# Altium schematic sheet DDR3-RAM.SchDoc(DDR3-RAM)
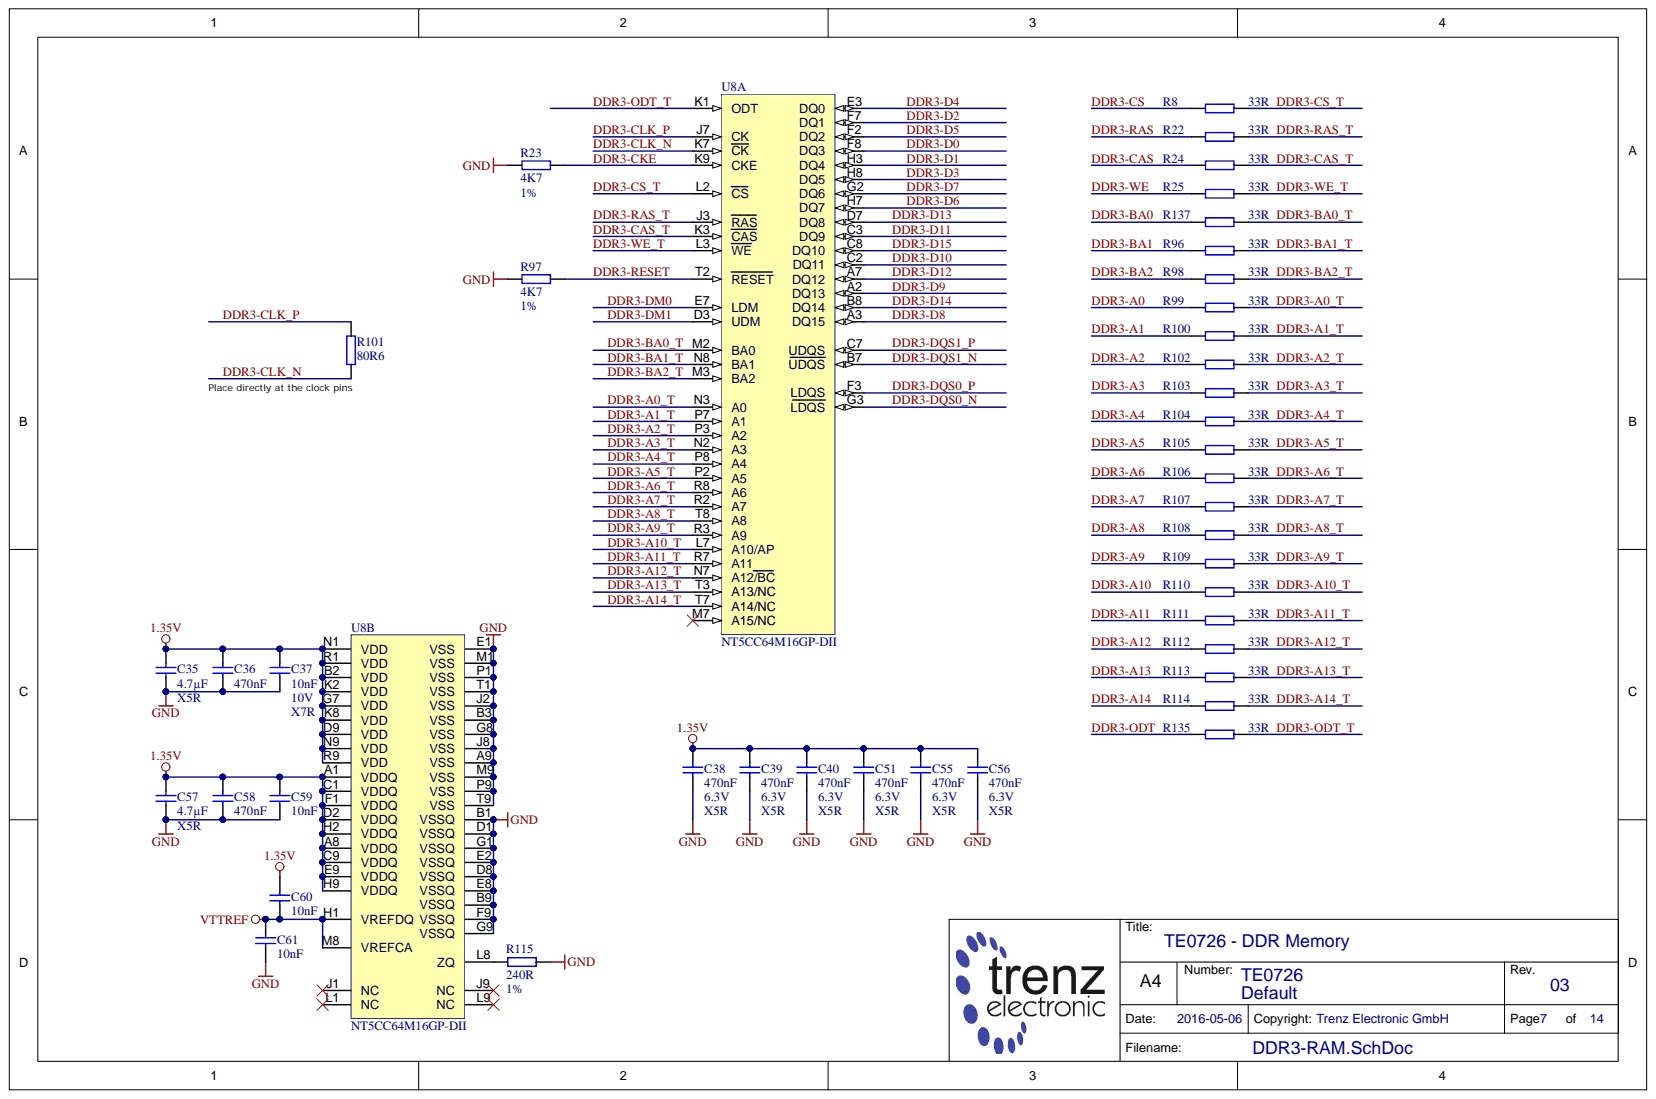
[diagram p0: DDR3-RAM.SchDoc]

## Parts
C35  4.7µF  Technology: X5R  [CAPC1005X60N]
C36  470nF  [CAPC0603X33N]
C37  10nF  Voltage: 10V  [CAPC0603X33N]
C38  470nF  Technology: X5R  Voltage: 6.3V  [CAPC0603X33N]
C39  470nF  Technology: X5R  Voltage: 6.3V  [CAPC0603X33N]
C40  470nF  Technology: X5R  Voltage: 6.3V  [CAPC0603X33N]
C51  470nF  Technology: X5R  Voltage: 6.3V  [CAPC0603X33N]
C55  470nF  Technology: X5R  Voltage: 6.3V  [CAPC0603X33N]
C56  470nF  Technology: X5R  Voltage: 6.3V  [CAPC0603X33N]
C57  4.7µF  Technology: X5R  [CAPC1005X60N]
C58  470nF  [CAPC0603X33N]
C59  10nF  [CAPC0603X33N]
C60  10nF  [CAPC0603X33N]
C61  10nF  [CAPC0603X33N]
R8  33R  [RESC0603X26N]
R22  33R  [RESC0603X26N]
R23  4K7  Tolerance: 1%  [RESC1005X40N]
R24  33R  [RESC0603X26N]
R25  33R  [RESC0603X26N]
R96  33R  [RESC0603X26N]
R97  4K7  Tolerance: 1%  [RESC1005X40N]
R98  33R  [RESC0603X26N]
R99  33R  [RESC0603X26N]
R100  33R  [RESC0603X26N]
R101  80R6  [RESC1005X40N]
R102  33R  [RESC0603X26N]
R103  33R  [RESC0603X26N]
R104  33R  [RESC0603X26N]
R105  33R  [RESC0603X26N]
R106  33R  [RESC0603X26N]
R107  33R  [RESC0603X26N]
R108  33R  [RESC0603X26N]
R109  33R  [RESC0603X26N]
R110  33R  [RESC0603X26N]
R111  33R  [RESC0603X26N]
R112  33R  [RESC0603X26N]
R113  33R  [RESC0603X26N]
R114  33R  [RESC0603X26N]
R115  240R  [RESC1005X40N]
R135  33R  [RESC0603X26N]
R137  33R  [RESC0603X26N]
U8  NT5CC64M16GP-DII  [BGA96C80P16X9_1300X800X100B45N]  (parts A,B)
U8  IM4G16D3FABG-125I  [BGA96C80P9X16_910X1360X120]  (value not drawn)  (part A)
U8  IM4G16D3FABG-125I  [BGA96C80P9X16_910X1360X120]  (value not drawn)  (part B)

## Nets
1.35V: C35.1 C36.1 C37.1 C38.1 C39.1 C40.1 C51.1 C55.1 C56.1 C57.1 C58.1 C59.1 C60.1 U8.A1 U8.A8 U8.B2 U8.C1 U8.C9 U8.D2 U8.D9 U8.E9 U8.F1 U8.G7 U8.H2 U8.H9 U8.K2 U8.K8 U8.N1 U8.N9 U8.R1 U8.R9
GND: C35.2 C36.2 C37.2 C38.2 C39.2 C40.2 C51.2 C55.2 C56.2 C57.2 C58.2 C59.2 C61.2 R23.1 R97.1 R115.2 U8.A9 U8.B1 U8.B3 U8.B9 U8.D1 U8.D8 U8.E1 U8.E2 U8.E8 U8.F9 U8.G1 U8.G8 U8.G9 U8.J2 U8.J8 U8.M1 U8.M9 U8.P1 U8.P9 U8.T1 U8.T9
VTTREF: C60.2 C61.1 U8.H1 U8.M8
DDR3-A0: R99.1
DDR3-A0_T: R99.2 U8.N3
DDR3-A1: R100.1
DDR3-A1_T: R100.2 U8.P7
DDR3-A2: R102.1
DDR3-A2_T: R102.2 U8.P3
DDR3-A3: R103.1
DDR3-A3_T: R103.2 U8.N2
DDR3-A4: R104.1
DDR3-A4_T: R104.2 U8.P8
DDR3-A5: R105.1
DDR3-A5_T: R105.2 U8.P2
DDR3-A6: R106.1
DDR3-A6_T: R106.2 U8.R8
DDR3-A7: R107.1
DDR3-A7_T: R107.2 U8.R2
DDR3-A8: R108.1
DDR3-A8_T: R108.2 U8.T8
DDR3-A9: R109.1
DDR3-A9_T: R109.2 U8.R3
DDR3-A10: R110.1
DDR3-A10_T: R110.2 U8.L7
DDR3-A11: R111.1
DDR3-A11_T: R111.2 U8.R7
DDR3-A12: R112.1
DDR3-A12_T: R112.2 U8.N7
DDR3-A13: R113.1
DDR3-A13_T: R113.2 U8.T3
DDR3-A14: R114.1
DDR3-A14_T: R114.2 U8.T7
DDR3-BA0: R137.1
DDR3-BA0_T: R137.2 U8.M2
DDR3-BA1: R96.1
DDR3-BA1_T: R96.2 U8.N8
DDR3-BA2: R98.1
DDR3-BA2_T: R98.2 U8.M3
DDR3-CAS: R24.1
DDR3-CAS_T: R24.2 U8.K3
DDR3-CKE: R23.2 U8.K9
DDR3-CLK_N: R101.2 U8.K7
DDR3-CLK_P: R101.1 U8.J7
DDR3-CS: R8.1
DDR3-CS_T: R8.2 U8.L2
DDR3-D0: U8.F8
DDR3-D1: U8.H3
DDR3-D2: U8.F7
DDR3-D3: U8.H8
DDR3-D4: U8.E3
DDR3-D5: U8.F2
DDR3-D6: U8.H7
DDR3-D7: U8.G2
DDR3-D8: U8.A3
DDR3-D9: U8.A2
DDR3-D10: U8.C2
DDR3-D11: U8.C3
DDR3-D12: U8.A7
DDR3-D13: U8.D7
DDR3-D14: U8.B8
DDR3-D15: U8.C8
DDR3-DM0: U8.E7
DDR3-DM1: U8.D3
DDR3-DQS0_N: U8.G3
DDR3-DQS0_P: U8.F3
DDR3-DQS1_N: U8.B7
DDR3-DQS1_P: U8.C7
DDR3-ODT: R135.1
DDR3-ODT_T: R135.2 U8.K1
DDR3-RAS: R22.1
DDR3-RAS_T: R22.2 U8.J3
DDR3-RESET: R97.2 U8.T2
DDR3-WE: R25.1
DDR3-WE_T: R25.2 U8.L3
NetR115_1: R115.1 U8.L8

Not connected: U8 J1 J9 L1 L9 M7

## Library data of the parts
C35 C57 = 24719: KON 4.7µF 6.3V X5R SMD 20%; Database Table Name: C_1005_0402; Mixed: SMT; Preferred: Yes; Value: 4.7u; Voltage: 6.3; AN: 24719; Case: 1005 (0402); Component Kind: Standard; Library Name: TE.DbLib; Tolerance: 20%
C36 C38-C40 C51 C55 C56 C58 = 24921: KON 470nF X5R 6.3V SMD 20%; Database Table Name: C_0603_0201; Mixed: SMT; Preferred: Yes; Value: 470n; AN: 24921; Case: 0603 (0201); Component Kind: Standard; Library Name: TE.DbLib; Tolerance: 20%
  C36 C58: Technology: X5R; Voltage: 6.3V
C37 C59-C61 = 26312: KON 10nF X7R 10V SMD 10%; Database Table Name: C_0603_0201; Mixed: SMT; Preferred: Yes; Value: 10n; AN: 26312; Case: 0603 (0201); Component Kind: Standard; Library Name: TE.DbLib; Technology: X7R; Tolerance: 10%
  C59-C61: Voltage: 10V
R8 R22 R24 R25 R96 R98-R100 R102-R114 R135 R137 = 25021: WID SMD 33R 1% 0,05W; Database Table Name: R_0603_0201; Mixed: SMT; Preferred: Yes; Value: 33; AN: 25021; Case: 0603 (0201); Component Kind: Standard; Library Name: TE.DbLib; Technology: TK100; Tolerance: 1%; HelpURL: B:\AD\adlib\datasheet\R0201
R23 R97 = 22585: WID SMD 4K7 1% 0,063W; Database Table Name: R_1005_0402; Mixed: SMT; Preferred: Yes; Value: 4.7K; AN: 22585; Case: 1005 (0402); Component Kind: Standard; Library Name: TE.DbLib; Technology: TK100
R101 = 25100: WID SMD 80R6 1% 0,063W; Database Table Name: R_1005_0402; Mixed: SMT; Preferred: Yes; Value: 80.6; AN: 25100; Case: 1005 (0402); Component Kind: Standard; Library Name: TE.DbLib; Technology: TK100; Tolerance: 1%
R115 = 23661: WID SMD 240R 1% 0,063W; Database Table Name: R_1005_0402; Mixed: SMT; Preferred: Yes; Value: 240; AN: 23661; Case: 1005 (0402); Component Kind: Standard; Library Name: TE.DbLib; Technology: TK100; Tolerance: 1%
U8 = 27039: Database Table Name: DDR; Mixed: SMT; Trenz-Stock: Yes; AN: 27039; Case: VFBGA96; Component Kind: Standard; Description: NT5CC64M16GP-DII; H-ART: NT5CC64M16GP-DII; Library Name: TE.DbLib; HelpURL: B:\ad\adlib\datasheet\NT5Cx64M16GP
U8 U8 = 27202: Database Table Name: DDR; Mixed: SMT; Preferred: Yes; AN: 27202; Case: TFBGA96; Component Kind: Standard; Description: IM4G16D3FABG-125I; H-ART: IM4G16D3FABG-125I; Library Name: TE.DbLib; Trenz-Stock: Yes; HelpURL: B:\AD\adlib\datasheet\IM4GxxD3FABG
